# Altium schematic sheet USB.SchDoc
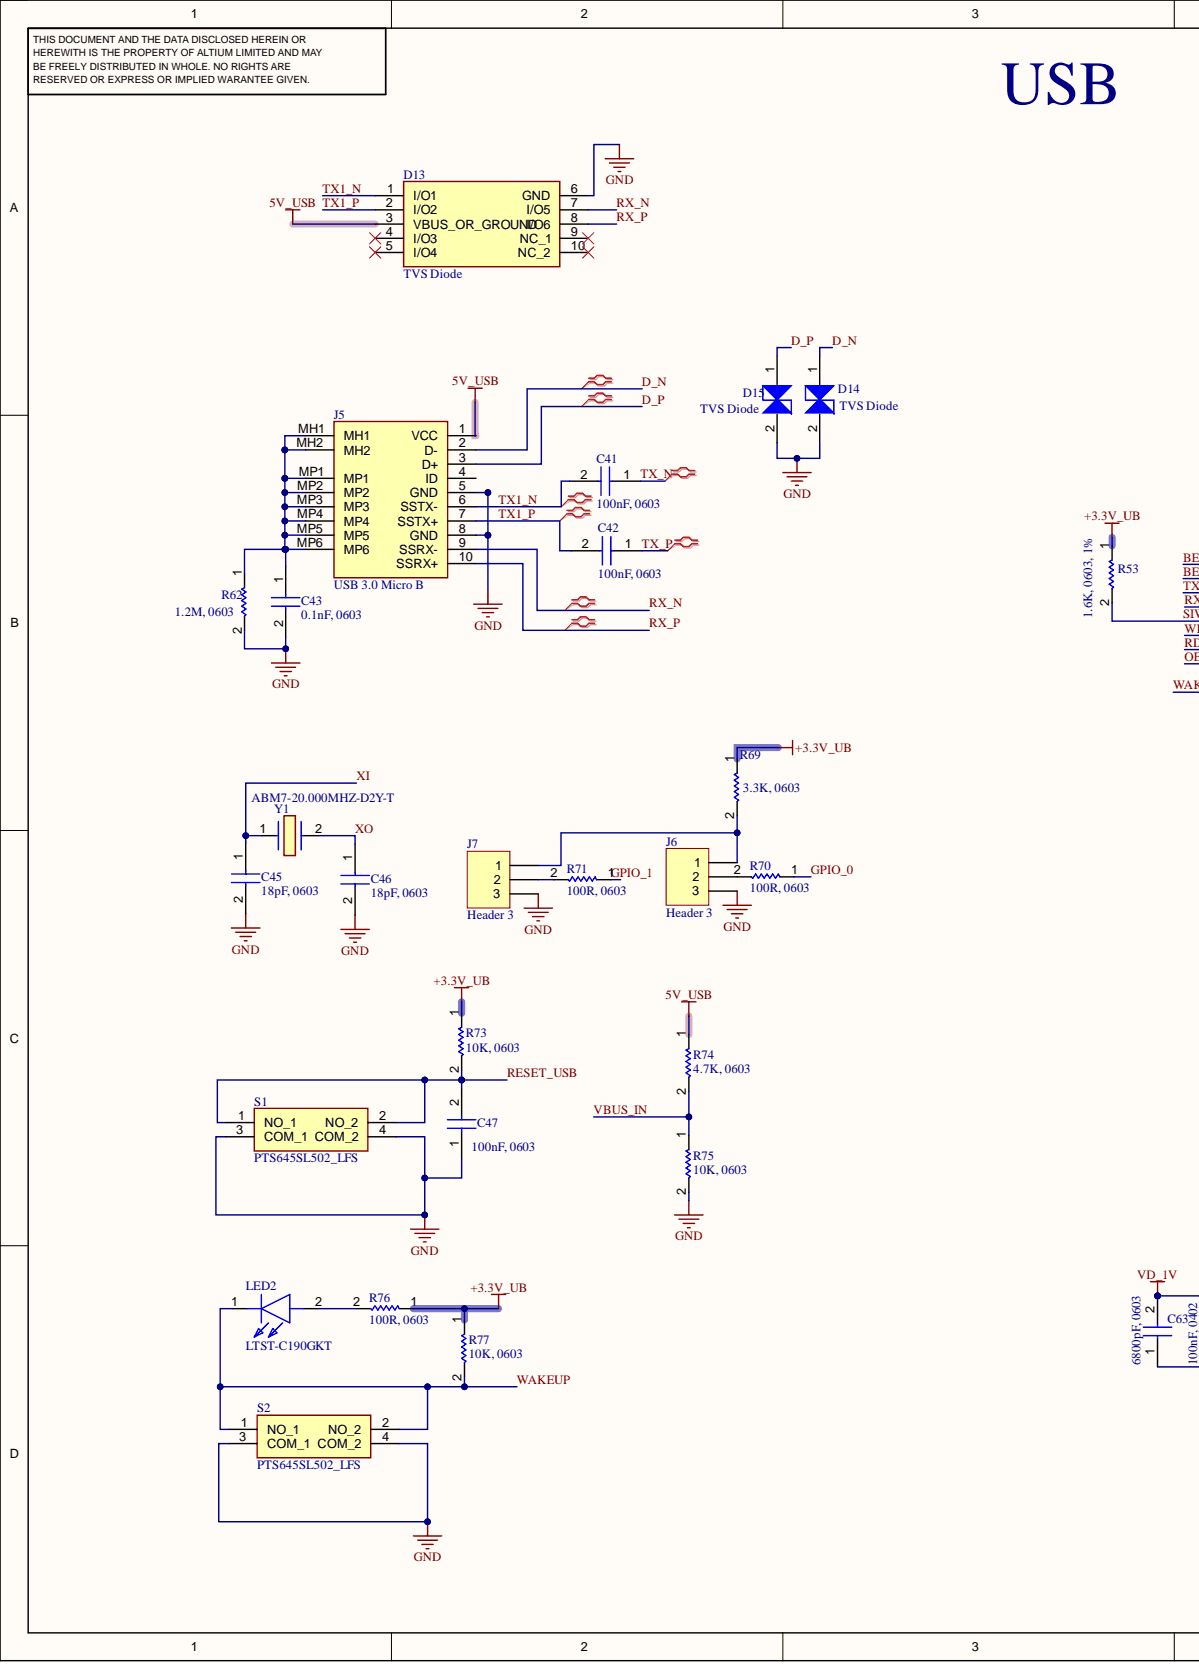
[diagram p0: USB.SchDoc, left]
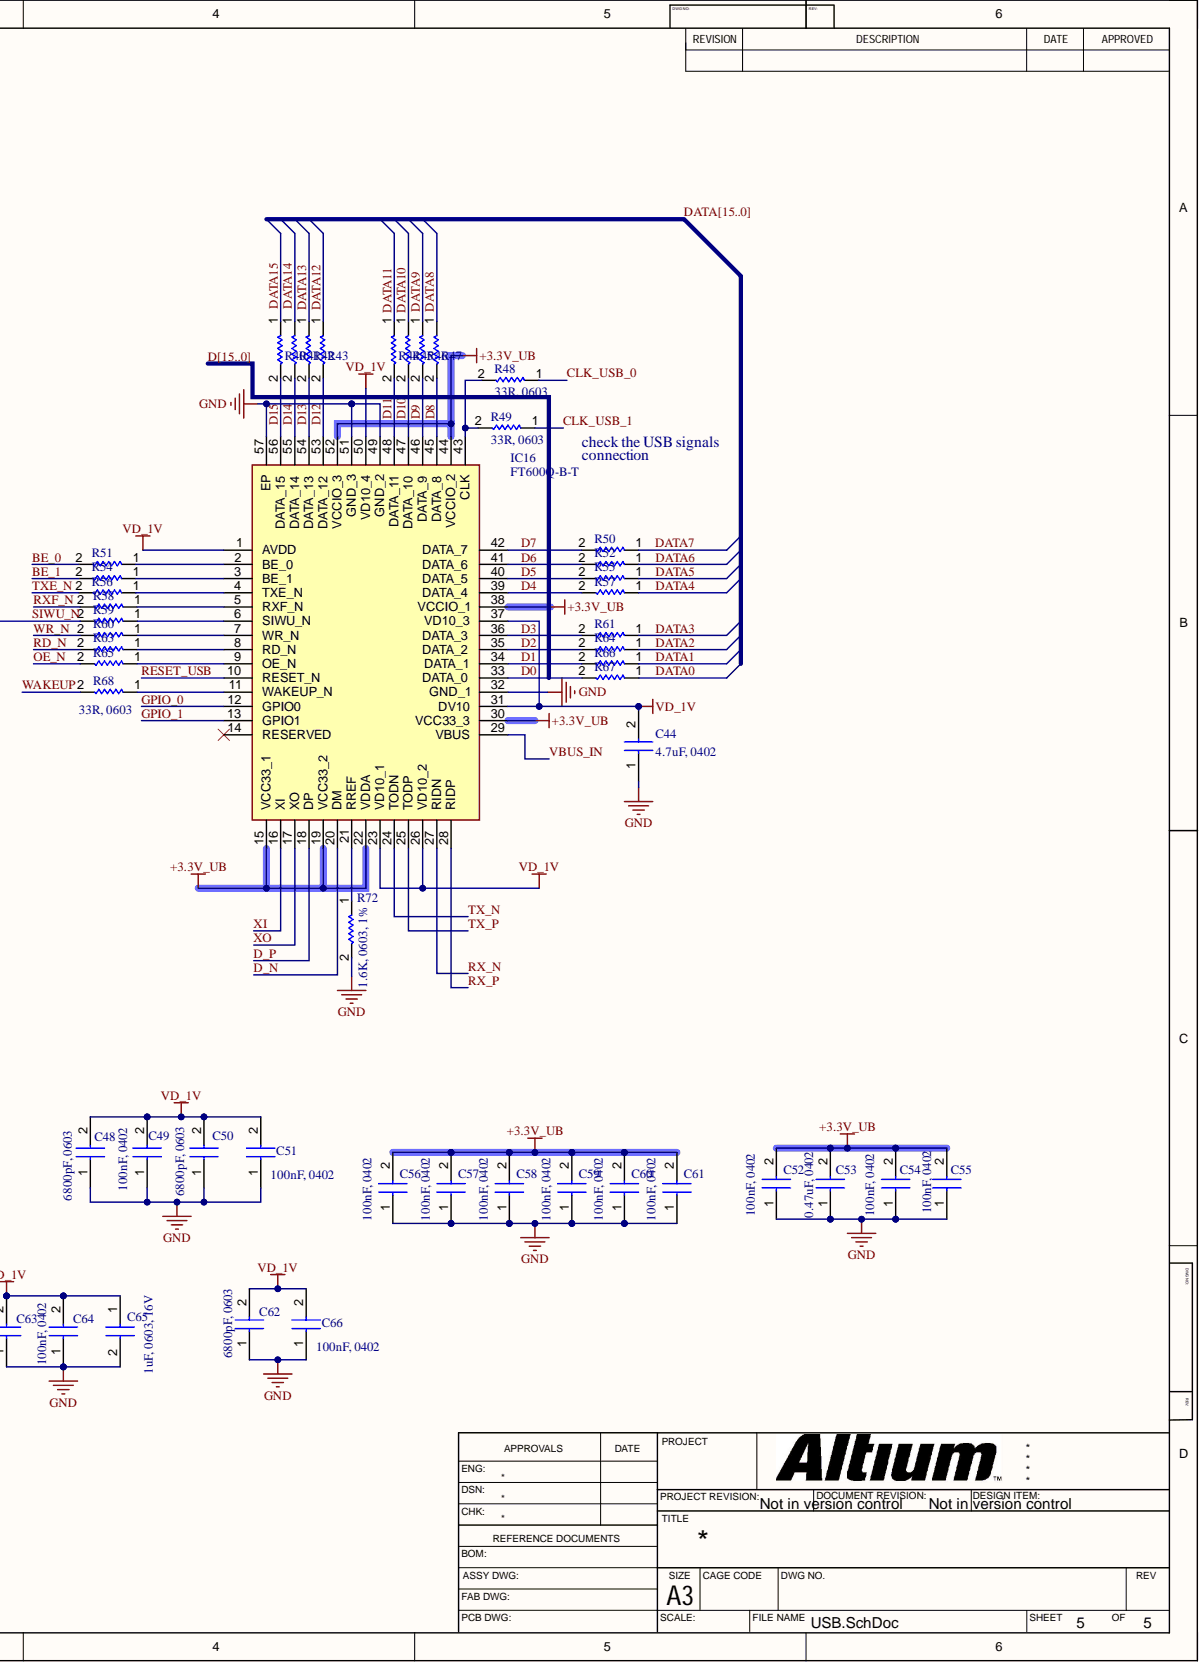
[diagram p1: USB.SchDoc, right]

## Parts
C41  100nF, 0603  [CAP0603]
C42  100nF, 0603  [CAP0603]
C43  0.1nF, 0603  [CAP0603]
C44  4.7uF, 0402  [CAP0402]
C45  18pF, 0603  [CAP0603]
C46  18pF, 0603  [CAP0603]
C47  100nF, 0603  [CAP0603]
C48  6800pF, 0603  [CAP0603]
C49  100nF, 0402  [CAP0402]
C50  6800pF, 0603  [CAP0603]
C51  100nF, 0402  [CAP0402]
C52  100nF, 0402  [CAP0402]
C53  0.47uF, 0402  [CAP0402]
C54  100nF, 0402  [CAP0402]
C55  100nF, 0402  [CAP0402]
C56  100nF, 0402  [CAP0402]
C57  100nF, 0402  [CAP0402]
C58  100nF, 0402  [CAP0402]
C59  100nF, 0402  [CAP0402]
C60  100nF, 0402  [CAP0402]
C61  100nF, 0402  [CAP0402]
C62  6800pF, 0603  [CAP0603]
C63  6800pF, 0603  [CAP0603]
C64  100nF, 0402  [CAP0402]
C65  1uF, 0603, 16V  [CAP0603]
C66  100nF, 0402  [CAP0402]
D13  TVS Diode  [ESD8006MUTAG]
D14  TVS Diode  [VARC1005X39N]
D15  TVS Diode  [VARC1005X39N]
IC16  FT600Q-B-T  [QFN40P700X700X90-57N-D]
J5  USB 3.0 Micro B  [ZX360DB10P30]
J6  Header 3  [HDR1X3]
J7  Header 3  [HDR1X3]
LED2  LTST-C190GKT  [LEDC1608X90N]
R40  33R, 0603  [RES0603]  (value not drawn)
R41  33R, 0603  [RES0603]  (value not drawn)
R42  33R, 0603  [RES0603]  (value not drawn)
R43  33R, 0603  [RES0603]  (value not drawn)
R44  33R, 0603  [RES0603]  (value not drawn)
R45  33R, 0603  [RES0603]  (value not drawn)
R46  33R, 0603  [RES0603]  (value not drawn)
R47  33R, 0603  [RES0603]  (value not drawn)
R48  33R, 0603  [RES0603]
R49  33R, 0603  [RES0603]
R50  33R, 0603  [RES0603]  (value not drawn)
R51  33R, 0603  [RES0603]  (value not drawn)
R52  33R, 0603  [RES0603]  (value not drawn)
R53  1.6K, 0603, 1%  [RES0603]
R54  33R, 0603  [RES0603]  (value not drawn)
R55  33R, 0603  [RES0603]  (value not drawn)
R56  33R, 0603  [RES0603]  (value not drawn)
R57  33R, 0603  [RES0603]  (value not drawn)
R58  33R, 0603  [RES0603]  (value not drawn)
R59  33R, 0603  [RES0603]  (value not drawn)
R60  33R, 0603  [RES0603]  (value not drawn)
R61  33R, 0603  [RES0603]  (value not drawn)
R62  1.2M, 0603  [RES0603]
R63  33R, 0603  [RES0603]  (value not drawn)
R64  33R, 0603  [RES0603]  (value not drawn)
R65  33R, 0603  [RES0603]  (value not drawn)
R66  33R, 0603  [RES0603]  (value not drawn)
R67  33R, 0603  [RES0603]  (value not drawn)
R68  33R, 0603  [RES0603]
R69  3.3K, 0603  [RES0603]
R70  100R, 0603  [RES0603]
R71  100R, 0603  [RES0603]
R72  1.6K, 0603, 1%  [RES0603]
R73  10K, 0603  [RES0603]
R74  4.7K, 0603  [RES0603]
R75  10K, 0603  [RES0603]
R76  100R, 0603  [RES0603]
R77  10K, 0603  [RES0603]
S1  PTS645SL502_LFS  [PTS645SL502LFS]
S2  PTS645SL502_LFS  [PTS645SL502LFS]
Y1  ABM7-20.000MHZ-D2Y-T  [ABM7]

## Nets
5V_USB: D13.3(VBUS_OR_GROUND) J5.1(VCC) R74.1
+3.3V_UB: C52.2 C53.2 C54.2 C55.2 C56.2 C57.2 C58.2 C59.2 C60.2 C61.2 IC16.15(VCC33_1) IC16.19(VCC33_2) IC16.22(VDDA) IC16.30(VCC33_3) IC16.38(VCCIO_1) IC16.44(VCCIO_2) IC16.52(VCCIO_3) R53.1 R69.1 R73.1 R76.1 R77.1
GND: C43.2 C44.1 C45.2 C46.2 C47.1 C48.1 C49.1 C50.1 C51.1 C52.1 C53.1 C54.1 C55.1 C56.1 C57.1 C58.1 C59.1 C60.1 C61.1 C62.1 C63.1 C64.1 C65.2 C66.1 D13.6(GND) D14.2 D15.2 IC16.32(GND_1) IC16.49(GND_2) IC16.51(GND_3) IC16.57(EP) J5.5(GND) J5.8(GND) J6.3 J7.3 R62.2 R72.2 R75.2 S1.3(COM_1) S1.4(COM_2) S2.3(COM_1) S2.4(COM_2)
VD_1V: C44.2 C48.2 C49.2 C50.2 C51.2 C62.2 C63.2 C64.2 C65.1 C66.2 IC16.1(AVDD) IC16.23(VD10_1) IC16.26(VD10_2) IC16.31(DV10) IC16.37(VD10_3) IC16.50(VD10_4)
BE_0: R51.2
BE_1: R54.2
CLK_USB_0: R48.1
CLK_USB_1: R49.1
D0: IC16.33(DATA_0) R67.2  [also labelled D]
D1: IC16.34(DATA_1) R66.2  [also labelled D]
D2: IC16.35(DATA_2) R64.2  [also labelled D]
D3: IC16.36(DATA_3) R61.2  [also labelled D]
D4: IC16.39(DATA_4) R57.2  [also labelled D]
D5: IC16.40(DATA_5) R55.2  [also labelled D]
D6: IC16.41(DATA_6) R52.2  [also labelled D]
D7: IC16.42(DATA_7) R50.2  [also labelled D]
D8: IC16.45(DATA_8) R47.2  [also labelled D]
D9: IC16.46(DATA_9) R46.2  [also labelled D]
D10: IC16.47(DATA_10) R45.2  [also labelled D]
D11: IC16.48(DATA_11) R44.2  [also labelled D]
D12: IC16.53(DATA_12) R43.2  [also labelled D]
D13: IC16.54(DATA_13) R42.2  [also labelled D]
D14: IC16.55(DATA_14) R41.2  [also labelled D]
D15: IC16.56(DATA_15) R40.2  [also labelled D]
D_N: D14.1 IC16.20(DM) J5.2(D-)
D_P: D15.1 IC16.18(DP) J5.3(D+)
DATA0: R67.1  [also labelled DATA]
DATA1: R66.1  [also labelled DATA]
DATA2: R64.1  [also labelled DATA]
DATA3: R61.1  [also labelled DATA]
DATA4: R57.1  [also labelled DATA]
DATA5: R55.1  [also labelled DATA]
DATA6: R52.1  [also labelled DATA]
DATA7: R50.1  [also labelled DATA]
DATA8: R47.1  [also labelled DATA]
DATA9: R46.1  [also labelled DATA]
DATA10: R45.1  [also labelled DATA]
DATA11: R44.1  [also labelled DATA]
DATA12: R43.1  [also labelled DATA]
DATA13: R42.1  [also labelled DATA]
DATA14: R41.1  [also labelled DATA]
DATA15: R40.1  [also labelled DATA]
GPIO_0: IC16.12(GPIO0) R70.1
GPIO_1: IC16.13(GPIO1) R71.1
OE_N: R65.2
RD_N: R63.2
RESET_USB: C47.2 IC16.10(RESET_N) R73.2 S1.1(NO_1) S1.2(NO_2)
RX_N: D13.7(I/O5) IC16.27(RIDN) J5.9(SSRX-)
RX_P: D13.8(I/O6) IC16.28(RIDP) J5.10(SSRX+)
RXF_N: R58.2
SIWU_N: R53.2 R59.2
TX1_N: C41.2 D13.1(I/O1) J5.6(SSTX-)
TX1_P: C42.2 D13.2(I/O2) J5.7(SSTX+)
TX_N: C41.1 IC16.24(TODN)
TX_P: C42.1 IC16.25(TODP)
TXE_N: R56.2
VBUS_IN: IC16.29(VBUS) R74.2 R75.1
WAKEUP: LED2.1 R68.2 R77.2 S2.1(NO_1) S2.2(NO_2)
WR_N: R60.2
XI: C45.1 IC16.16(XI) Y1.1
XO: C46.1 IC16.17(XO) Y1.2
NetC43_1: C43.1 J5.MH1 J5.MH2 J5.MP1 J5.MP2 J5.MP3 J5.MP4 J5.MP5 J5.MP6 R62.1
NetIC16_2: IC16.2(BE_0) R51.1
NetIC16_3: IC16.3(BE_1) R54.1
NetIC16_4: IC16.4(TXE_N) R56.1
NetIC16_5: IC16.5(RXF_N) R58.1
NetIC16_6: IC16.6(SIWU_N) R59.1
NetIC16_7: IC16.7(WR_N) R60.1
NetIC16_8: IC16.8(RD_N) R63.1
NetIC16_9: IC16.9(OE_N) R65.1
NetIC16_11: IC16.11(WAKEUP_N) R68.1
NetIC16_21: IC16.21(RREF) R72.1
NetIC16_43: IC16.43(CLK) R48.2 R49.2
NetJ6_1: J6.1 J7.1 R69.2
NetJ6_2: J6.2 R70.2
NetJ7_2: J7.2 R71.2
NetLED2_2: LED2.2 R76.2

Not connected: D13 4(I/O3) 5(I/O4) 9(NC_1) 10(NC_2); IC16 14(RESERVED); J5 4(ID)

## Library data of the parts
C41 C42 C47 = Capacitor: CAP CER 0.1UF 16V X7R 0603; Manufacturer_Name: YAGEO; Manufacturer_Part_Number: CC0603KRX7R7BB104; Component Kind: Standard; Library Name: Sanket.SchLib
C43 = Capacitor: CAP CER 100PF 10V X8R 0603; Manufacturer_Name: KEMET; Manufacturer_Part_Number: C0603C101F8HACTU; Component Kind: Standard; Library Name: Sanket.SchLib
C44 = Capacitor: CAP CER 4.7UF 10V X5R 0402; Manufacturer_Name: Samsung Electro-Mechanics; Manufacturer_Part_Number: CL05A475MP5NRNC; Component Kind: Standard; Library Name: Sanket.SchLib
C45 C46 = Capacitor: CAP CER 0603 18PF 10V ULTRA STAB; Manufacturer_Name: KEMET; Manufacturer_Part_Number: C0603C180F8HACAUTO; Component Kind: Standard; Library Name: Sanket.SchLib
C48 C50 C62 C63 = Capacitor: CAP CER 6800PF 10V U2J 0603; Manufacturer_Name: Murata Electronics; Manufacturer_Part_Number: GRM1857U1A682JA44D; Component Kind: Standard; Library Name: Sanket.SchLib
C49 C51 C52 C54-C61 C64 C66 = Capacitor: CAP CER 0.1UF 16V X7R 0402; Manufacturer_Name: Samsung Electro-Mechanics; Manufacturer_Part_Number: CL05B104KO5NNNC; Component Kind: Standard; Library Name: Sanket.SchLib
C53 = Capacitor: CAP CER 0.47UF 10V X7R 0402; Manufacturer_Name: YAGEO; Manufacturer_Part_Number: CC0402KRX7R6BB474; Component Kind: Standard; Library Name: Sanket.SchLib
C65 = Capacitor: CAP CER 1UF 16V X7R 0603; Manufacturer_Name: Samsung Electro-Mechanics; Manufacturer_Part_Number: CL10B105KO8NNNC; Component Kind: Standard; Library Name: Sanket.SchLib
D13 = ESD7016MUTAG: TVS DIODE 5VWM 10VC 8UDFN; Manufacturer_Name: onsemi; Component Kind: Standard; Manufacturer_Part_Number: ESD7016MUTAG
D14 D15 = PGB1010402KR: TVS DIODE 12VWM 250VC 0402; Manufacturer_Name: LITTELFUSE; Component Kind: Standard; Manufacturer_Part_Number: PGB1010402KR; Library Name: Sanket.SchLib
IC16 = FT600Q-B-T: Integrated Circuit; Manufacturer_Name: FTDI Chip; Component Kind: Standard; Manufacturer_Part_Number: FT600Q-B-T
J5 = ZX360D-B-10P_30_: CONN RCPT USB3.0 MICRO B SMD R/A; Manufacturer_Name: Hirose; Manufacturer_Part_Number: ZX360D-B-10P(30); Component Kind: Standard; Library Name: Sanket.SchLib
J6 J7 = Header 3: Header, 3-Pin; Component Kind: Standard; Library Name: Miscellaneous Connectors.IntLib; Signal Integrity: Connector
LED2 = LTST-C190GKT: LED; Manufacturer_Name: Lite-On; Component Kind: Standard; Manufacturer_Part_Number: LTST-C190GKT
R40-R52 R54-R61 R63-R68 = Resistor 0603: RES 33 OHM 1% 1/10W 0603; Manufacturer_Name: Vishay Dale; Manufacturer_Part_Number: CRCW060333R0FKEAC; Component Kind: Standard; Library Name: Sanket.SchLib
R53 R72 = Resistor 0603: RES 1.6K OHM 1% 1/10W 0603; Manufacturer_Name: YAGEO; Manufacturer_Part_Number: RC0603FR-071K6L; Component Kind: Standard; Library Name: Sanket.SchLib
R62 = Resistor 0603: RES 1.2M OHM 1% 1/10W 0603; Manufacturer_Name: YAGEO; Manufacturer_Part_Number: RC0603FR-071M2L; Component Kind: Standard; Library Name: Sanket.SchLib
R69 = Resistor 0603: RES 3.3K OHM 5% 1/10W 0603; Manufacturer_Name: Stackpole Electronics Inc; Manufacturer_Part_Number: RMCF0603JT3K30; Component Kind: Standard; Library Name: Sanket.SchLib
R70 R71 R76 = Resistor 0603: RES SMD 100 OHM 1% 1/8W 0603; Manufacturer_Name: Vishay Dale; Manufacturer_Part_Number: CRCW0603100RFKEA; Component Kind: Standard; Library Name: Sanket.SchLib
R73 R75 R77 = Resistor 0603: RES 10K OHM 1% 1/10W 0603; Manufacturer_Name: YAGEO; Manufacturer_Part_Number: RC0603FR-0710KL; Component Kind: Standard; Library Name: Sanket.SchLib
R74 = Resistor 0603: RES 4.7K OHM 1% 1/10W 0603; Manufacturer_Name: YAGEO; Manufacturer_Part_Number: RC0603FR-074K7L; Component Kind: Standard; Library Name: Sanket.SchLib
S1 S2 = PTS645SL502_LFS: Switch; Manufacturer_Name: C & K COMPONENTS; Manufacturer_Part_Number: PTS645SL502 LFS; Component Kind: Standard
Y1 = ABM7-20.000MHZ-D2Y-T: Crystal or Oscillator; Manufacturer_Name: ABRACON; Component Kind: Standard; Manufacturer_Part_Number: ABM7-20.000MHZ-D2Y-T
